# Altium schematic sheet Power_Iso.SchDoc("Isolated Power")
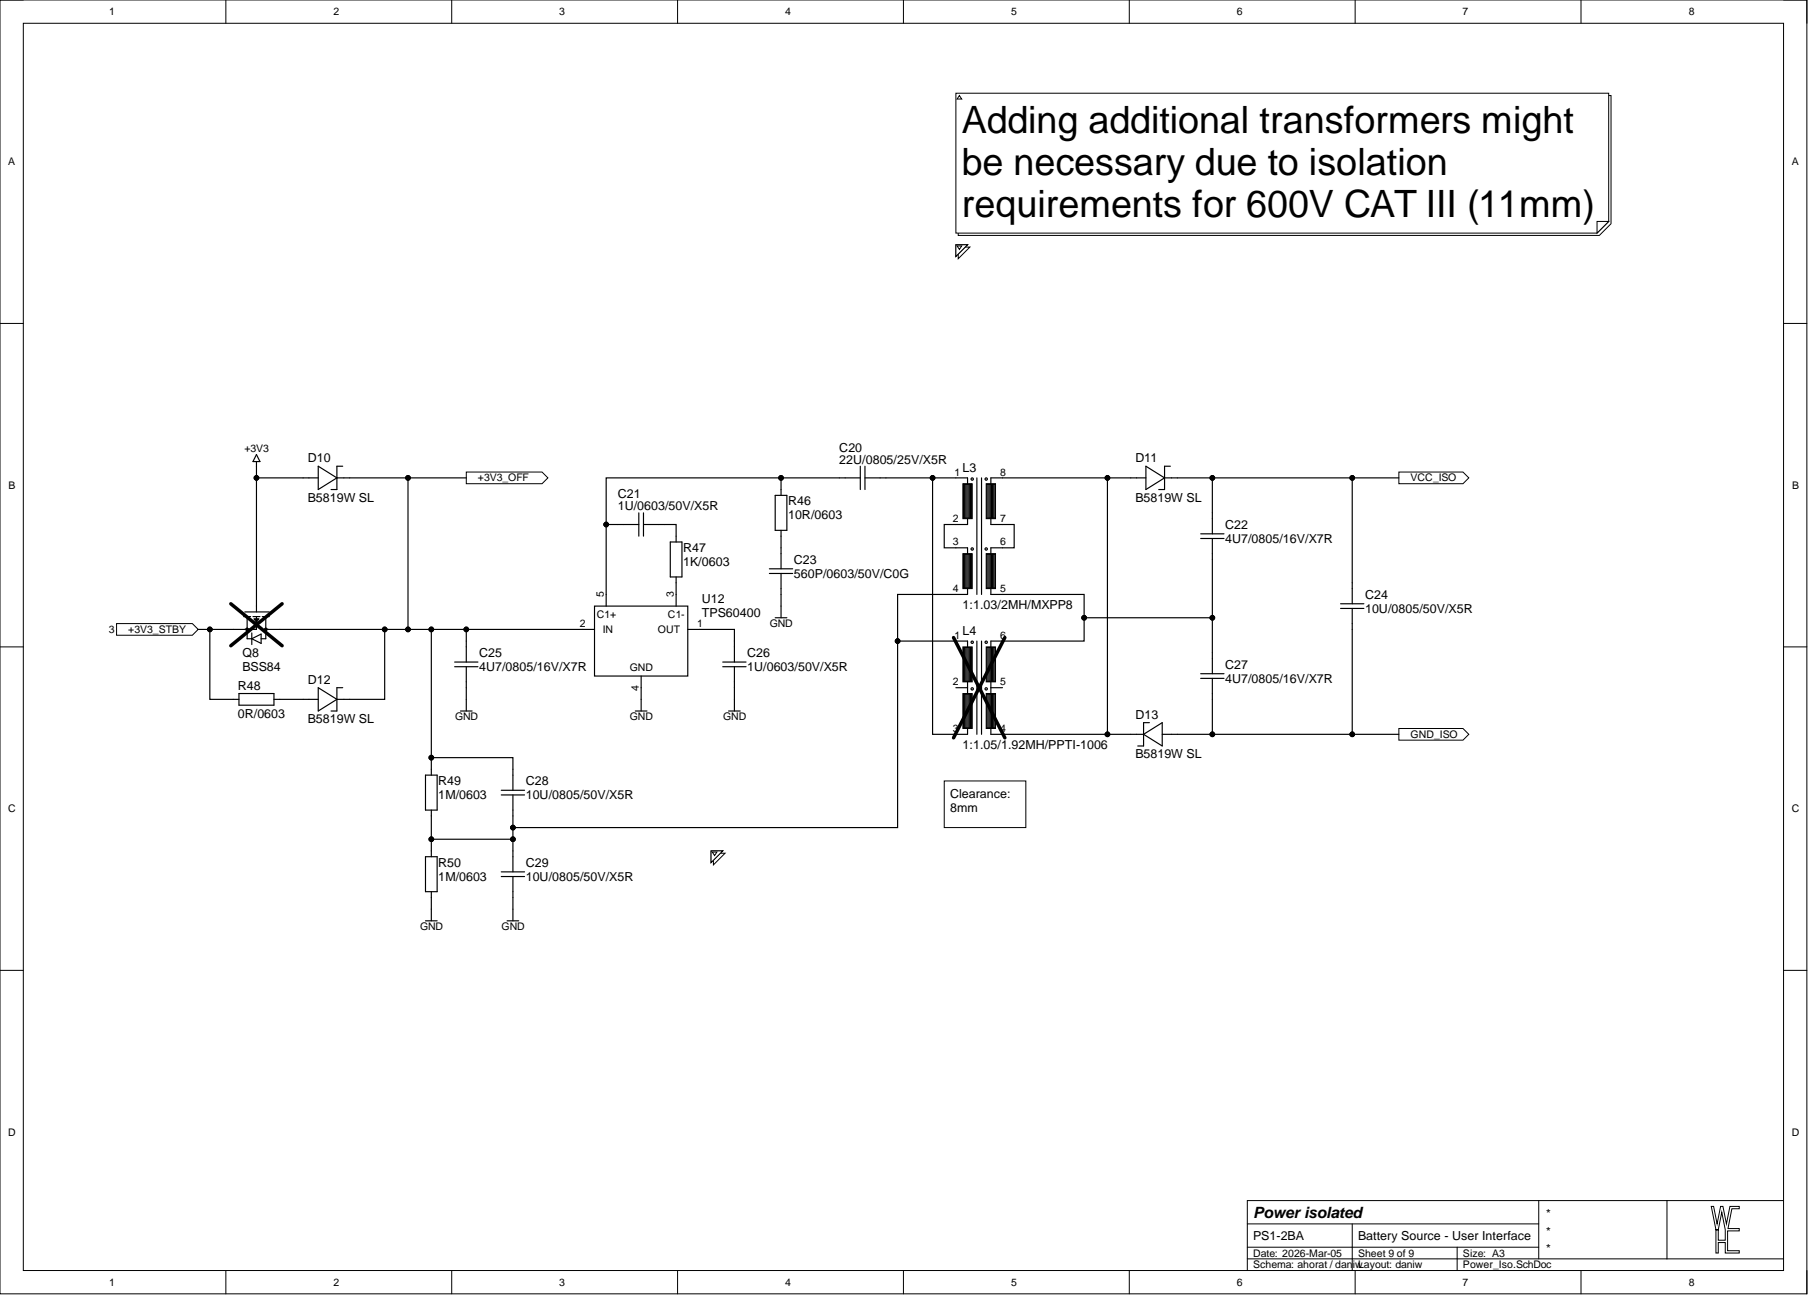
[diagram p0: Power_Iso.SchDoc]

## Parts
C20  22U/0805/25V/X5R  [CAP0805_X7R]
C21  1U/0603/50V/X5R  [CAP0603_X7R]
C22  4U7/0805/16V/X7R  [CAP0805_X7R]
C23  560P/0603/50V/C0G  [CAP0603_C0G]
C24  10U/0805/50V/X5R  [CAP0805_X7R]
C25  4U7/0805/16V/X7R  [CAP0805_X7R]
C26  1U/0603/50V/X5R  [CAP0603_X7R]
C27  4U7/0805/16V/X7R  [CAP0805_X7R]
C28  10U/0805/50V/X5R  [CAP0805_X7R]
C29  10U/0805/50V/X5R  [CAP0805_X7R]
D10  B5819W SL  [SOD3718X125]
D11  B5819W SL  [SOD3718X125]
D12  B5819W SL  [SOD3718X125]
D13  B5819W SL  [SOD3718X125]
L3  1:1.03/2MH/MXPP8  [TR_MAXIN_MXPP8]
L4  1:1.05/1.92MH/PPTI-1006  [TR_WE_PPTI_1006-467]
Q8  BSS84  [SOT2395P280X125-3-SE]
R46  10R/0603  [R0603-0.40-WW]
R47  1K/0603  [R0603-0.40-WW]
R48  0R/0603  [R0603-0.40-WW]
R49  1M/0603  [R0603-0.40-WW]
R50  1M/0603  [R0603-0.40-WW]
U12  TPS60400  [SOT2395P280X125-5-SW]

## Ports
+3V3_OFF, +3V3_STBY, GND_ISO, VCC_ISO

## Nets
+3V3: D10.2 Q8.1
GND: C23.2 C25.2 C26.2 C29.2 R50.2 U12.4
+3V3_OFF: C25.1 C28.1 D10.1 D12.1 Q8.3 R49.1 U12.2(IN) ; port +3V3_OFF
+3V3_STBY: Q8.2 R48.1 ; port +3V3_STBY
GND_ISO: C24.2 C27.2 D13.2 ; port GND_ISO
VCC_ISO: C22.1 C24.1 D11.1 ; port VCC_ISO
NetC20_1: C20.1 C21.1 R46.1 U12.5
NetC20_2: C20.2 L3.1 L4.3
NetC21_2: C21.2 R47.1
NetC22_2: C22.2 C27.1 L3.5 L4.6
NetC23_1: C23.1 R46.2
NetC26_1: C26.1 U12.1(OUT)
NetC28_2: C28.2 C29.1 L3.4 L4.1 R49.2 R50.1
NetD11_2: D11.2 D13.1 L3.8 L4.4
NetD12_2: D12.2 R48.2
NetL3_2: L3.2 L3.3
NetL3_6: L3.6 L3.7
NetL4_2: L4.2
NetL4_5: L4.5
NetR47_2: R47.2 U12.3

## Library data of the parts
C20 = 22U/0805/25V/X5R: CAP SM 0805 22uF 25V X5R; Manufacturer: GENERIC; Manufacturer 1: Samsung Electro-Mechanics; Manufacturer Part Number 1: CL21A226MAYNNNE; Absolute Value: 2.2E-5; Database Table Name: C$; Eurocircuits GPN: GPN0805106-25; Component Kind: Standard; HelpURL: https://mm.digikey.com/Volume0/opasdata/d220001/medias/docus/339/CL21A226MAYNNNE_Spec.pdf; JLC Type: Basic; Manufacturer Part Number 3: CL21A226MAQNNNE; Package: 0805; Part Number: 22U/0805/25V/X5R; Supplier 1: Digi-Key; Supplier 3: LCSC; Supplier Part Number 1: 1276-CL21A226MAYNNNECT-ND; Supplier Part Number 3: C45783; Value: 22uF; Library Name: daniw_altium_lib.DbLib
C21 C26 = 1U/0603/50V/X5R: CAP SM 0603 1.0uF 50V X5R; Manufacturer: GENERIC; Manufacturer 1: Samsung Electro-Mechanics; Manufacturer Part Number 1: CL10A105KB8NNNC; Absolute Value: 1E-6; Database Table Name: C$; Eurocircuits GPN: GPC0603105-X5R; Component Kind: Standard; HelpURL: https://mm.digikey.com/Volume0/opasdata/d220001/medias/docus/658/CL10A105KB8NNNC_Spec.pdf; JLC Type: Basic; Manufacturer Part Number 3: CL10A105KB8NNNC; Package: 0603; Part Number: 1U/0603/50V/X5R; Supplier 1: Digi-Key; Supplier 3: LCSC; Supplier Part Number 1: 1276-1860-1-ND; Supplier Part Number 3: C15849; Value: 1.0uF; Library Name: daniw_altium_lib.DbLib
C22 C25 C27 = 4U7/0805/16V/X7R: CAP SM 0805 4.7uF 16V X7R; Manufacturer: GENERIC; Manufacturer 1: Murata; Manufacturer Part Number 1: GRM21BR71C475KE51L; Absolute Value: 4.7E-6; Database Table Name: C$; Eurocircuits GPN: GPN0805475-16; Component Kind: Standard; HelpURL: https://search.murata.co.jp/Ceramy/image/img/A01X/G101/ENG/GRM21BR71C475KE51-01.pdf; Manufacturer Part Number 3: TCC0603X5R475M160CT; Package: 0805; Part Number: 4U7/0805/16V/X7R; Supplier 1: Digi-Key; Supplier 3: LCSC; Supplier Part Number 1: 490-14466-1-ND; Supplier Part Number 3: C7393897; Value: 4.7uF; Library Name: daniw_altium_lib.DbLib
C23 = 560P/0603/50V/C0G: CAP SM 0603 560pF 50V C0G; Manufacturer: GENERIC; Manufacturer 1: YAGEO; Manufacturer Part Number 1: CC0603JRNPO9BN561; Absolute Value: 5.6E-10; Database Table Name: C$; Eurocircuits GPN: GPC0603561-C0G; Component Kind: Standard; HelpURL: https://www.yageo.com/upload/media/product/productsearch/datasheet/mlcc/UPY-GP_NP0_16V-to-50V_18.pdf; JLC Type: Extended; Manufacturer 2: YAGEO; Manufacturer Part Number 2: CC0603GRNPO9BN561; Manufacturer Part Number 3: CC0603JRNPO9BN561; Package: 0603; Part Number: 560P/0603/50V/C0G; Supplier 1: Digi-Key; Supplier 2: Digi-Key; Supplier 3: LCSC; Supplier Part Number 1: 311-3359-1-ND; Supplier Part Number 2: 311-3937-1-ND; Supplier Part Number 3: C107054; Value: 560pF; Library Name: daniw_altium_lib.DbLib
C24 C28 C29 = 10U/0805/50V/X5R: CAP SM 0805 10uF 50V X5R; Manufacturer: GENERIC; Manufacturer 1: Murata Electronics; Manufacturer Part Number 1: GRM21BR61H106KE43K; Absolute Value: 1E-5; Database Table Name: C$; Eurocircuits GPN: GPN0805106-50-X5R; Component Kind: Standard; HelpURL: https://search.murata.co.jp/Ceramy/image/img/A01X/G101/ENG/GRM21BR61H106KE43-01A.pdf; JLC Type: Basic; Manufacturer Part Number 3: GRM21BR61H106KE43L; Package: 0805; Part Number: 10U/0805/50V/X5R; Supplier 1: Digi-Key; Supplier 3: LCSC; Supplier Part Number 1: 490-GRM21BR61H106KE43KCT-ND; Supplier Part Number 3: C440198; Value: 10uF; Library Name: daniw_altium_lib.DbLib
D10-D13 = B5819W SL: 40V 600mV@1A 1A SOD-123 Schottky Barrier Diodes; Manufacturer: GENERIC; Manufacturer 1: SMC Diode Solutions; Database Table Name: D$; Absolute Value: 40V; Component Kind: Standard; HelpURL: https://datasheet.lcsc.com/lcsc/2304140030_Jiangsu-Changjing-Electronics-Technology-Co---Ltd--B5819W-SL_C8598.pdf; JLC Type: Basic; Manufacturer 3: Jiangsu Changjing Electronics Technology Co., Ltd.; Manufacturer Part Number 1: B5819WSL; Manufacturer Part Number 3: B5819W SL; Package: SOD-123; Part Number: B5819W SL; Supplier 1: Digikey; Supplier 3: LCSC; Supplier Part Number 1: 1655-B5819WSLCT-ND; Supplier Part Number 3: C8598; Value: B5819W SL; Library Name: daniw_altium_lib.DbLib
L3 = 1:1.03/2MH/MXPP8: MXPP8 Push-Pull Transformer, Turns ratio 1:1.03, 2mH, Size MXPP8; Manufacturer: Wuxi Maxinmicro; Database Table Name: L$; Component Kind: Standard; HelpURL: https://www.lcsc.com/datasheet/C19943836.pdf; JLC Type: Extended; Manufacturer 3: Wuxi Maxinmicro; Manufacturer Part Number 3: MXPP8-30-1103; Package: MXPP8; Part Number: 1:1.03/2MH/MXPP8; Supplier 3: LCSC; Supplier Part Number 3: C19943836; Library Name: daniw_altium_lib.DbLib
L4 = 1:1.05/1.92MH/PPTI-1006: WE-PPTI Push-Pull Transformer, Turns ratio 1:1.05, 1.92mH, Size 1006, 750317829; Manufacturer: WE; Manufacturer 1: Würth Elektronik; Database Table Name: L$; Component Kind: Standard; HelpURL: https://www.we-online.com/components/products/datasheet/750317829.pdf; Manufacturer 2: Wurth Elektronik; Manufacturer Part Number 1: 750317829; Manufacturer Part Number 2: 750317829; Package: 1006; Part Number: 1:1.05/1.92MH/PPTI-1006; Supplier 1: Digi-Key; Supplier 2: Mouser; Supplier Part Number 1: 1297-750317829CT-ND; Supplier Part Number 2: 710-750317829; Library Name: daniw_altium_lib.DbLib
Q8 = BSS84: 50V P-Channel MOSFET; Manufacturer: GENERIC; Manufacturer 1: Good-Ark Semiconductor; Database Table Name: T$; Component Kind: Standard; HelpURL: https://goodarksemi.com/docs/datasheets/mosfets/BSS84.pdf; JLC Type: Extended; Manufacturer 2: LRC; Manufacturer 3: Jiangsu Changjing Electronics Technology Co., Ltd.; Manufacturer Part Number 1: BSS84; Manufacturer Part Number 2: LBSS84LT1G; Manufacturer Part Number 3: BSS84; Package: SOT23; Part Number: BSS84; Supplier 1: Digi-Key; Supplier 2: LCSC; Supplier 3: LCSC; Supplier Part Number 1: 4786-BSS84CT-ND; Supplier Part Number 2: C8492; Supplier Part Number 3: C114481; Library Name: daniw_altium_lib.DbLib
R46 = 10R/0603: RES SM 0603 10R; Manufacturer: GENERIC; Manufacturer 1: YAGEO; Manufacturer Part Number 1: RC0603FR-1310RL; Absolute Value: 10; Database Table Name: R$; Eurocircuits GPN: GPR060310R; Component Kind: Standard; HelpURL: https://www.yageogroup.com/content/datasheet/asset/file/PYU-RC_GROUP_51_ROHS_L; JLC Type: Basic; Manufacturer 2: YAGEO; Manufacturer 3: UNI-ROYAL; Manufacturer Part Number 2: RC0603FR-1010RL; Manufacturer Part Number 3: 0603WAF100JT5E; Package: 0603; Part Number: 10R/0603; Supplier 1: Digi-Key; Supplier 2: Digi-Key; Supplier 3: LCSC; Supplier Part Number 1: 13-RC0603FR-1310RLCT-ND; Supplier Part Number 2: 13-RC0603FR-1010RLCT-ND; Supplier Part Number 3: C22859; Value: 10R; Library Name: daniw_altium_lib.DbLib
R47 = 1K/0603: RES SM 0603 1K; Manufacturer: GENERIC; Manufacturer 1: YAGEO; Manufacturer Part Number 1: RC0603FR-131KL; Absolute Value: 1000; Database Table Name: R$; Eurocircuits GPN: GPR06031K; Component Kind: Standard; HelpURL: https://www.yageogroup.com/content/datasheet/asset/file/PYU-RC_GROUP_51_ROHS_L; JLC Type: Basic; Manufacturer 2: YAGEO; Manufacturer 3: UNI-ROYAL(Uniroyal Elec); Manufacturer Part Number 2: RC0603FR-101KL; Manufacturer Part Number 3: 0603WAF1001T5E; Package: 0603; Part Number: 1K/0603; Supplier 1: Digi-Key; Supplier 2: Digi-Key; Supplier 3: LCSC; Supplier Part Number 1: 13-RC0603FR-131KLCT-ND; Supplier Part Number 2: 13-RC0603FR-101KLCT-ND; Supplier Part Number 3: C21190; Value: 1K; Library Name: daniw_altium_lib.DbLib
R48 = 0R/0603: RES SM 0603 0R; Manufacturer: GENERIC; Manufacturer 1: YAGEO; Manufacturer Part Number 1: RC0603FR-070RL; Absolute Value: 0; Database Table Name: R$; Eurocircuits GPN: GPR06030R; Component Kind: Standard; HelpURL: https://www.yageogroup.com/content/datasheet/asset/file/PYU-RC_GROUP_51_ROHS_L; JLC Type: Basic; Manufacturer 2: YAGEO; Manufacturer 3: UNI-ROYAL(Uniroyal Elec); Manufacturer Part Number 2: RC0603FR-130RL; Manufacturer Part Number 3: 0603WAF0000T5E; Package: 0603; Part Number: 0R/0603; Supplier 1: Digi-Key; Supplier 2: Digi-Key; Supplier 3: LCSC; Supplier Part Number 1: 311-0.0HRCT-ND; Supplier Part Number 2: 13-RC0603FR-130RLCT-ND; Supplier Part Number 3: C21189; Value: 0R; Library Name: daniw_altium_lib.DbLib
R49 R50 = 1M/0603: RES SM 0603 1M; Manufacturer: GENERIC; Manufacturer 1: YAGEO; Manufacturer Part Number 1: RC0603FR-131ML; Absolute Value: 1000000; Database Table Name: R$; Eurocircuits GPN: GPR06031M; Component Kind: Standard; HelpURL: https://www.yageogroup.com/content/datasheet/asset/file/PYU-RC_GROUP_51_ROHS_L; JLC Type: Basic; Manufacturer 2: YAGEO; Manufacturer 3: UNI-ROYAL(Uniroyal Elec); Manufacturer Part Number 2: RC0603FR-101ML; Manufacturer Part Number 3: 0603WAF1004T5E; Package: 0603; Part Number: 1M/0603; Supplier 1: Digi-Key; Supplier 2: Digi-Key; Supplier 3: LCSC; Supplier Part Number 1: 13-RC0603FR-131MLCT-ND; Supplier Part Number 2: 13-RC0603FR-101MLCT-ND; Supplier Part Number 3: C22935; Value: 1M; Library Name: daniw_altium_lib.DbLib
U12 = TPS60400: TPS60400 Unregulated 60-mA Charge Pump Voltage Inverter; Manufacturer: Texas Instruments; Manufacturer Part Number 1: TPS60400DBVR; Database Table Name: Integrated$; Component Kind: Standard; HelpURL: https://www.ti.com/lit/ds/symlink/tps60400.pdf; JLC Type: Extended; Manufacturer 1: Texas Instruments; Manufacturer 2: Texas Instruments; Manufacturer 3: TI; Manufacturer Part Number 2: TPS60400DBVT; Manufacturer Part Number 3: TPS60400DBVR; Package: SOT23-5; Part Number: TPS60400; Supplier 1: Digi-Key; Supplier 2: Digi-Key; Supplier 3: LCSC; Supplier Part Number 1: 296-27004-1-ND; Supplier Part Number 2: 296-12013-1-ND; Supplier Part Number 3: C9839; Library Name: daniw_altium_lib.DbLib
